# Altium schematic sheet ET-DAC.SchDoc
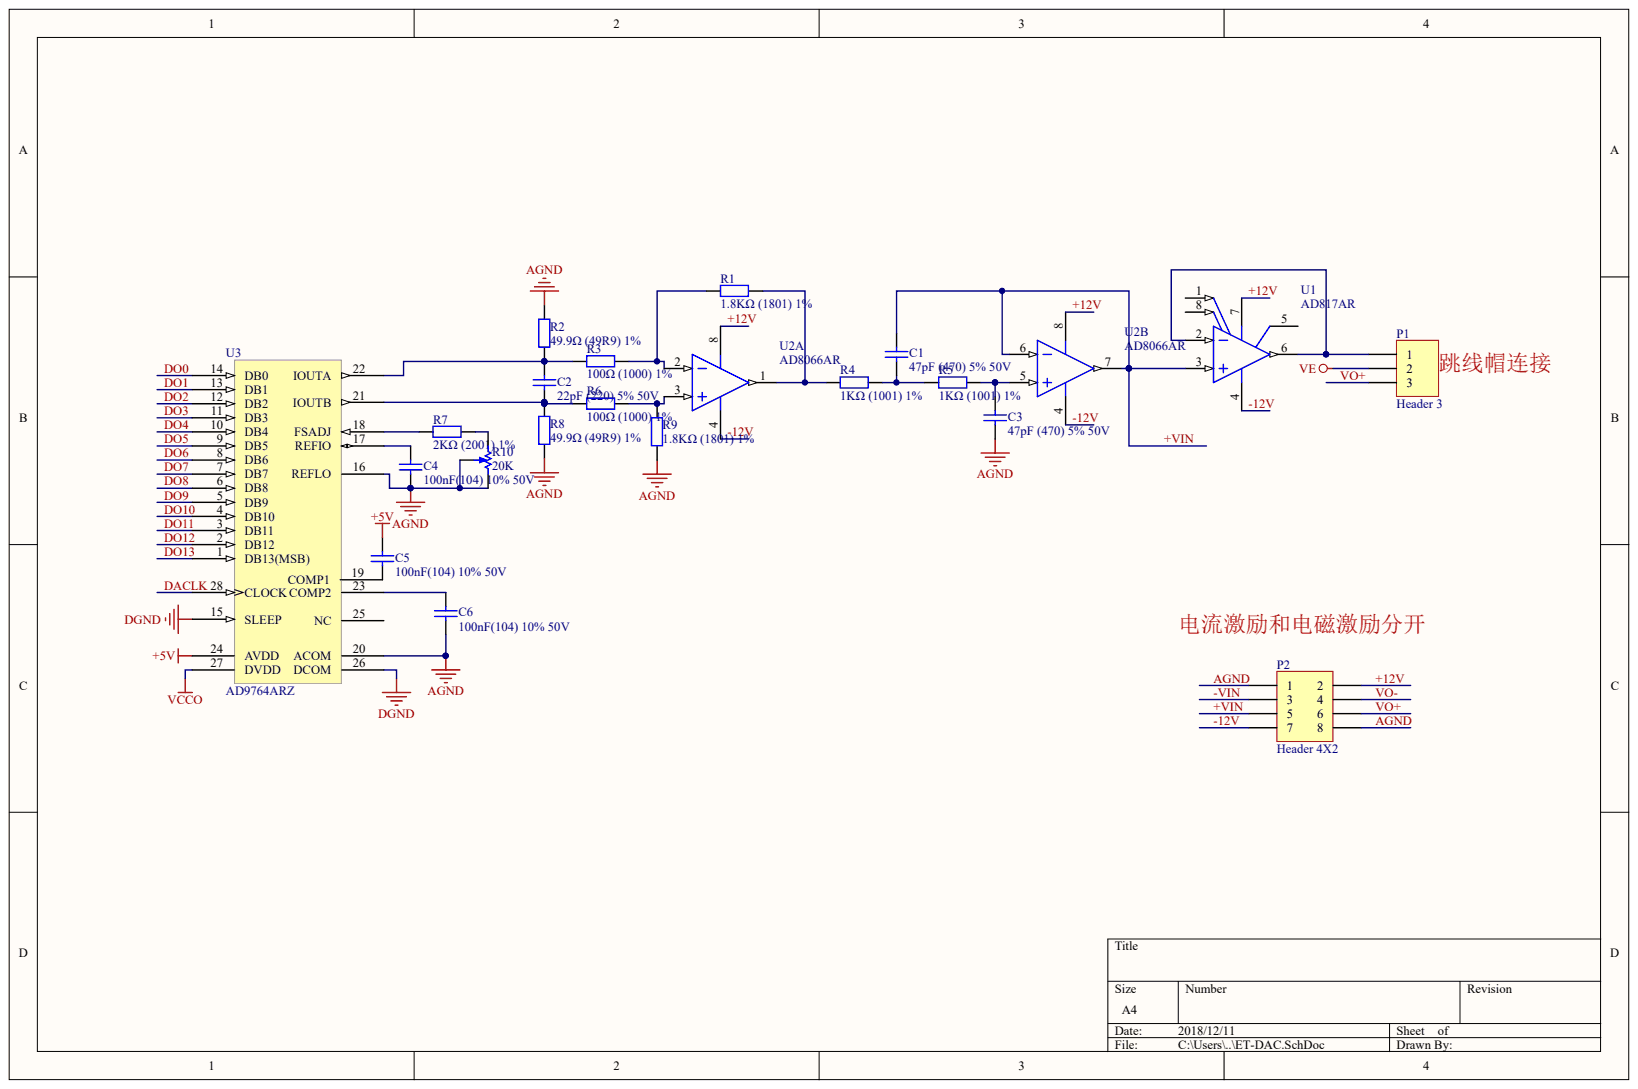
[diagram p0: ET-DAC.SchDoc]

## Parts
C1  47pF (470) 5% 50V
C2  22pF (220) 5% 50V
C3  47pF (470) 5% 50V
C4  100nF(104) 10% 50V
C5  100nF(104) 10% 50V
C6  100nF(104) 10% 50V
P1  Header 3
P2  Header 4X2
R1  1.8KΩ (1801) ±1%
R2  49.9Ω (49R9) ±1%
R3  100Ω (1000) ±1%
R4  1KΩ (1001) ±1%
R5  1KΩ (1001) ±1%
R6  100Ω (1000) ±1%
R7  2KΩ (2001) ±1%
R8  49.9Ω (49R9) ±1%
R9  1.8KΩ (1801) ±1%
R10  20K
U1  AD817AR
U2  AD8066AR  (parts A,B)
U3  AD9764ARZ

## Nets
+5V: C5.2 U3.24(AVDD)
DGND: U3.15(SLEEP) U3.26(DCOM)
VCCO: U3.27(DVDD)
VE: P1.2
+12V: P2.2 U1.7 U2.8
+VIN: C1.2 P2.5 U1.3 U2.6 U2.7
-12V: P2.7 U1.4 U2.4
-VIN: P2.3
AGND: C3.2 C4.1 C6.1 P2.1 P2.8 R2.2 R8.1 R9.1 R10.CW R10.W U3.16(REFLO) U3.20(ACOM)
DACLK: U3.28(CLOCK)
DO0: U3.14(DB0)
DO1: U3.13(DB1)
DO2: U3.12(DB2)
DO3: U3.11(DB3)
DO4: U3.10(DB4)
DO5: U3.9(DB5)
DO6: U3.8(DB6)
DO7: U3.7(DB7)
DO8: U3.6(DB8)
DO9: U3.5(DB9)
DO10: U3.4(DB10)
DO11: U3.3(DB11)
DO12: U3.2(DB12)
DO13: U3.1(DB13(MSB))
VO+: P1.3 P2.6
VO-: P2.4
NetC1_1: C1.1 R4.2 R5.2
NetC2_1: C2.1 R6.1 R8.2 U3.21(IOUTB)
NetC2_2: C2.2 R2.1 R3.1 U3.22(IOUTA)
NetC3_1: C3.1 R5.1 U2.5
NetC4_2: C4.2 U3.17(REFIO)
NetC5_1: C5.1 U3.19(COMP1)
NetC6_2: C6.2 U3.23(COMP2)
NetP1_1: P1.1 U1.2 U1.6
NetR1_1: R1.1 R3.2 U2.2
NetR1_2: R1.2 R4.1 U2.1
NetR6_2: R6.2 R9.2 U2.3
NetR7_1: R7.1 U3.18(FSADJ)
NetR7_2: R7.2 R10.CCW
NetU1_1: U1.1
NetU1_8: U1.8

Not connected: U1 5; U3 25(NC)

## Library data of the parts
U1 = (no symbol name): Generic Part Number: AD817; Manufacturer: Analog Devices; Amplifiers Per Package: 1; Input Bias Current: 3.3uA; Input Offset Voltage: 500uV; Iq/Amp: 7.5mA; Slew Rate: 350V/us; Small Signal Bandwidth: 50MHz; V Span Max: 36V; V Span Min: 5V; DatasheetVersion: Rev. B; PackageVersion: 060506-A; PackageReference: R-8; PackageDescription: 8-Pin Standard Small Outline Package, Body 3.9 x 4.9 mm, Pitch 1.27 mm; Supplier 1: Digi-Key; Supplier Part Number 1: AD817AR-ND; Mounting Technology: Surface Mount; Code_JEDEC: MS-012-AA; PartNumber: AD817AR; ComponentLink1Description: Manufacturer URL; ComponentLink1URL: http://www.analog.com/en/index.html; ComponentLink2Description: Datasheet; ComponentLink2URL: http://www.analog.com/static/imported-files/data_sheets/AD817.pdf; ComponentLink3Description: Package Specification; ComponentLink3URL: http://www.analog.com/static/imported-files/packages/PKG_PDF/SOIC_Narrow%20(R)/R_8.pdf
U2 = (no symbol name): Generic Part Number: AD8066; Manufacturer: Analog Devices; Amplifiers Per Package: 2; Input Bias Current: 2pA; Input Offset Voltage: 400uV; Iq/Amp: 7.4mA; Slew Rate: 180V/us; Small Signal Bandwidth: 145MHz; V Span Max: 24V; V Span Min: 5V; DatasheetVersion: Rev. J; PackageVersion: 060506-A; PackageReference: R-8; PackageDescription: 8-Pin Standard Small Outline Package, Body 3.9 x 4.9 mm, Pitch 1.27 mm; Supplier 1: Digi-Key; Supplier Part Number 1: AD8066AR-ND; Mounting Technology: Surface Mount; Code_JEDEC: MS-012-AA; PartNumber: AD8066AR; ComponentLink1Description: Manufacturer URL; ComponentLink1URL: http://www.analog.com/en/index.html; ComponentLink2Description: Datasheet; ComponentLink2URL: http://www.analog.com/static/imported-files/data_sheets/AD8065_8066.pdf; ComponentLink3Description: Package Specification; ComponentLink3URL: http://www.analog.com/static/imported-files/packages/PKG_PDF/SOIC_Narrow%20(R)/R_8.pdf; ComponentLink4Description: Reference Circuit; ComponentLink4URL: http://www.analog.com/en/all-operational-amplifiers-op-amps/operational-amplifiers-op-amps/ad8066/products/product.html#product-recommendations
C1-C6 P1 P2 R1-R10 U3 = (no symbol name)
  C1 C3: ComponentLink1Description: 供应商链接; ComponentLink1URL: http://www.szlcsc.com/product/details_15529.html; Package: 0805; Supplier: LC; SuppliersPartNumber: C14857
  C2: ComponentLink1Description: 供应商链接; ComponentLink1URL: http://www.szlcsc.com/product/details_2156.html; Package: 0805; Supplier: LC; SuppliersPartNumber: C1804
  C4-C6: ComponentLink1Description: 供应商链接; ComponentLink1URL: http://www.szlcsc.com/product/details_50686.html; Package: 0805; Supplier: LC; SuppliersPartNumber: C49678
  P1 P2: LatestRevisionDate: 17-Jul-2002; LatestRevisionNote: Re-released for DXP Platform.
  R1 R9: ComponentLink1Description: 供应商链接; ComponentLink1URL: http://www.szlcsc.com/product/details_18086.html; Package: 0805; Supplier: LC; SuppliersPartNumber: C17398
  R2 R8: ComponentLink1Description: 供应商链接; ComponentLink1URL: http://www.szlcsc.com/product/details_18408.html; Package: 0805; Supplier: LC; SuppliersPartNumber: C17720
  R3 R6: ComponentLink1Description: 供应商链接; ComponentLink1URL: http://www.szlcsc.com/product/details_18096.html; Package: 0805; Supplier: LC; SuppliersPartNumber: C17408
  R4 R5: ComponentLink1Description: 供应商链接; ComponentLink1URL: http://www.szlcsc.com/product/details_18201.html; Package: 0805; Supplier: LC; SuppliersPartNumber: C17513
  R7: ComponentLink1Description: 供应商链接; ComponentLink1URL: http://www.szlcsc.com/product/details_18292.html; Package: 0805; Supplier: LC; SuppliersPartNumber: C17604
  R10: Published: 17-Dec-2003; DatasheetVersion: Oct-2003; PackageReference: POT4MM-2; PackageDescription: Square Trimming Potentiometer; ComponentLink1Description: Manufacturer Link; ComponentLink1URL: http://bourns.com/2/search.html
  U3: Manufacturer_Part_Number: AD9764ARZ; Vendor: Vendor; Value: Value; Type: Type; RefDes: Refdes
  P1 P2 R10: Publisher: Altium Limited
